# Altium schematic sheet 3A03xx_Oxocard_Mini_Basic_USB_PWR.SchDoc
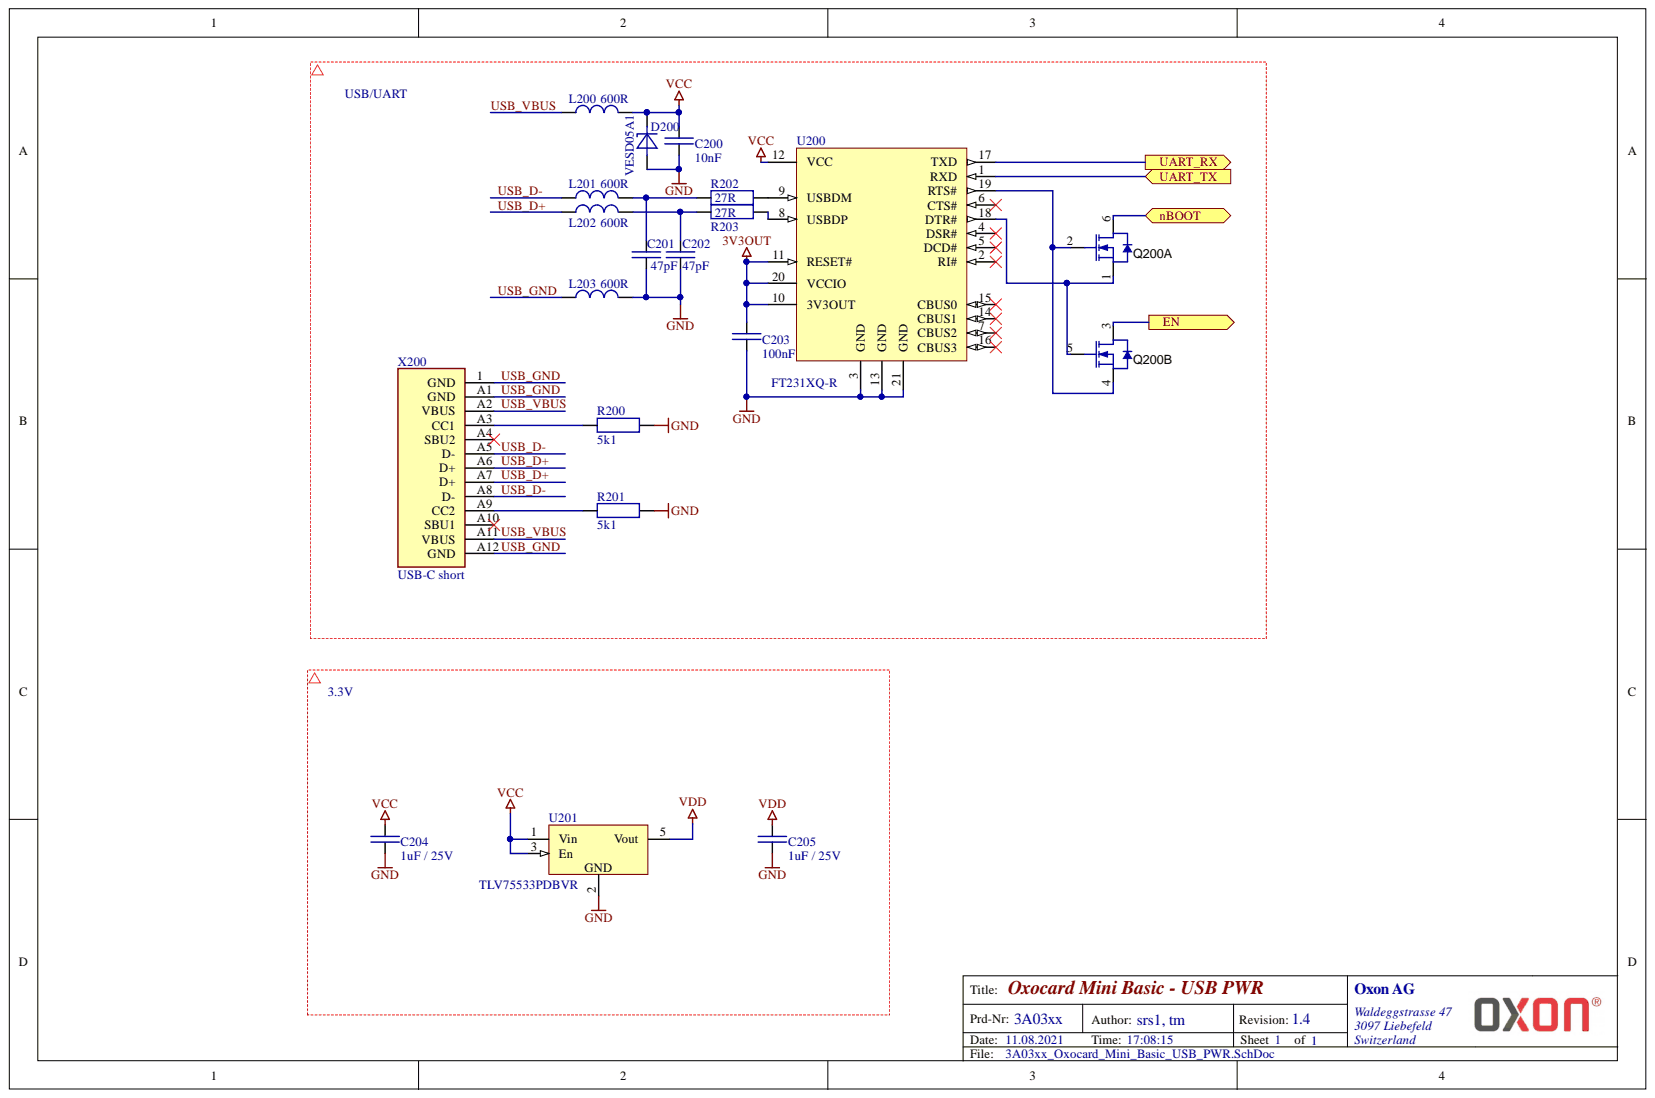
[diagram p0: 3A03xx_Oxocard_Mini_Basic_USB_PWR.SchDoc]

## Parts
C200  10nF  [CAPC1005X60]
C201  47pF  [CAPC1005X60]
C202  47pF  [CAPC1005X60]
C203  100nF  [CAPC1005X60]
C204  1uF / 25V  [CAPC1005X60]
C205  1uF / 25V  [CAPC1005X60]
D200  VESD05A1  [SOD-523]
L200  600R  [INDC1005X60]
L201  600R  [INDC1005X60]
L202  600R  [INDC1005X60]
L203  600R  [INDC1005X60]
Q200  Q-N_MOSFET_2Channel  [SOTFL50P160X60-6N]  (value not drawn)  (parts A,B)
R200  5k1  [RESC1005X40]
R201  5k1  [RESC1005X40]
R202  27R  [RESC1005X40]
R203  27R  [RESC1005X40]
U200  FT231XQ-R  [QFN20 4x4]
U201  TLV75533PDBVR  [SOT23/5]
X200  USB-C short  [X-JAE_DX07S016JA1R1500]

## Ports
EN, nBOOT, UART_RX, UART_TX

## Nets
3V3OUT: C203.2 U200.10(3V3OUT) U200.11(RESET#) U200.20(VCCIO)
GND: C200.1 C201.1 C202.1 C203.1 C204.1 C205.1 D200.2 L203.2 R200.2 R201.2 U200.3(GND) U200.13(GND) U200.21(GND) U201.2
VCC: C200.2 C204.2 D200.1 L200.2 U200.12(VCC) U201.1(Vin) U201.3(En)
VDD: C205.2 U201.5(Vout)
EN: Q200.3 ; port EN
nBOOT: Q200.6 ; port nBOOT
UART_RX: U200.17(TXD) ; port UART_RX
UART_TX: U200.1(RXD) ; port UART_TX
USB_D+: L202.1 X200.A6(D+) X200.A7(D+)
USB_D-: L201.1 X200.A5(D-) X200.A8(D-)
USB_GND: L203.1 X200.1(GND) X200.A1(GND) X200.A12(GND)
USB_VBUS: L200.1 X200.A2(VBUS) X200.A11(VBUS)
NetC201_2: C201.2 L201.2 R202.1
NetC202_2: C202.2 L202.2 R203.1
NetQ200_1: Q200.1 Q200.5 U200.18(DTR#)
NetQ200_2: Q200.2 Q200.4 U200.19(RTS#)
NetR200_1: R200.1 X200.A3(CC1)
NetR201_1: R201.1 X200.A9(CC2)
NetR202_2: R202.2 U200.9(USBDM)
NetR203_2: R203.2 U200.8(USBDP)

Not connected: U200 2(RI#) 4(DSR#) 5(DCD#) 6(CTS#) 7(CBUS2) 14(CBUS1) 15(CBUS0) 16(CBUS3); X200 A4(SBU2) A10(SBU1)

## Library data of the parts
C200 = C-CAP0402_10n: SMD capacitor 25V 5%; Manufacturer: Yageo; Manufacturer Designator: GCM155R71E103JA7D; Component Kind: Standard; Distributor: Mouser; Distributor Part-Number: 81-GCM155R71E103JA7D; Library Name: XLib.SCHLIB
C201 C202 = C-CAP0402_47p: SMD capacitor 50V 2%; Manufacturer: Yageo; Manufacturer Designator: CC402GRNPO9BN470; Component Kind: Standard; Distributor: Mouser; Distributor Part-Number: 603-CC402GRNPO9BN470; Library Name: XLib.SCHLIB
C203 = C-CAP0402_100n: SMD capacitor 10V 5%; Manufacturer: Wurth Electronics; Manufacturer Designator: GRM155R61A104JA1D; Component Kind: Standard; Distributor: Mouser; Distributor Part-Number: 81-GRM155R61A104JA1D; Library Name: XLib.SCHLIB
C204 C205 = C-CAP0402_1u: SMD capacitor 25V 10%; Manufacturer: Wurth Electronics; Manufacturer Designator: GRM155R61E105KA12J; Component Kind: Standard; Distributor: Mouser; Distributor Part-Number: 81-GRM155R61E105KA12J; Library Name: XLib.SCHLIB
D200 = D-Transient Voltage Suppressor 5V, 16A: Transient Voltage Suppressor, 5V, 16A; Manufacturer: Vishay; Manufacturer Designator: VESD05A1-02V-G-08; Component Kind: Standard; Distributor: Mouser; Distributor Part-Number: 78-VESD05A1-02V-G-08; Library Name: XLib.SCHLIB
L200-L203 = L-Ind00402_Ferrit600R: SMD Ferrit 25%; Manufacturer: muRata; Manufacturer Designator: BLM15PX601SN1D; Component Kind: Standard; Distributor: Mouser; Distributor Part-Number: 81-BLM15PX601SN1D; Library Name: XLib.SCHLIB
Q200 = Q-N_MOSFET_2Channel: MOSFET, 2x N-CH ,20V, 800mA; Manufacturer: Nexperia; Manufacturer Designator: PMDT290UNE,115; Component Kind: Standard; Distributor: Mouser; Distributor Part-Number: 771-PMDT290UNE,115; Library Name: XLib.SCHLIB
R200 R201 = R-RES0402_5k1: SMD resistor 1%; Manufacturer: ROHM Semiconductor; Manufacturer Designator: SFR01MZPF5101; Component Kind: Standard; Distributor: Mouser; Distributor Part-Number: 755-SFR01MZPF5101; Library Name: XLib.SCHLIB
R202 R203 = R-RES0402_27R: SMD resistor 1%; Manufacturer: Yageo; Manufacturer Designator: RT0402FRE0727RL; Component Kind: Standard; Distributor: Mouser; Distributor Part-Number: 603-RT0402FRE0727RL; Library Name: XLib.SCHLIB
U200 = U-FT231XQ-R: USB 2.0 to UART; Manufacturer: FTDI; Component Kind: Standard; Distributor: Mouser; Distributor Part-Number: 895-FT231XQ-R; Library Name: XLib.SCHLIB; Manufacturer Designator: FT231XQ-R
U201 = U-TLV75533PDBVR: LDO 3.3V 500mA; Manufacturer: Texas Instruments; Component Kind: Standard; Distributor: Mouser; Distributor Part-Number: 595-TLV75533PDBVR; Library Name: XLib.SCHLIB; Manufacturer Designator: TLV75533PDBVR
X200 = X-12-USB-C: USB C short; Manufacturer: JAE Electronics; Manufacturer Designator: DX07S016JA1R1500; Component Kind: Standard; Distributor: Mouser; Distributor Part-Number: 656-DX07S016JA1R1500; Library Name: XLib.SCHLIB
